# Altium schematic sheet Function.SchDoc(Function)
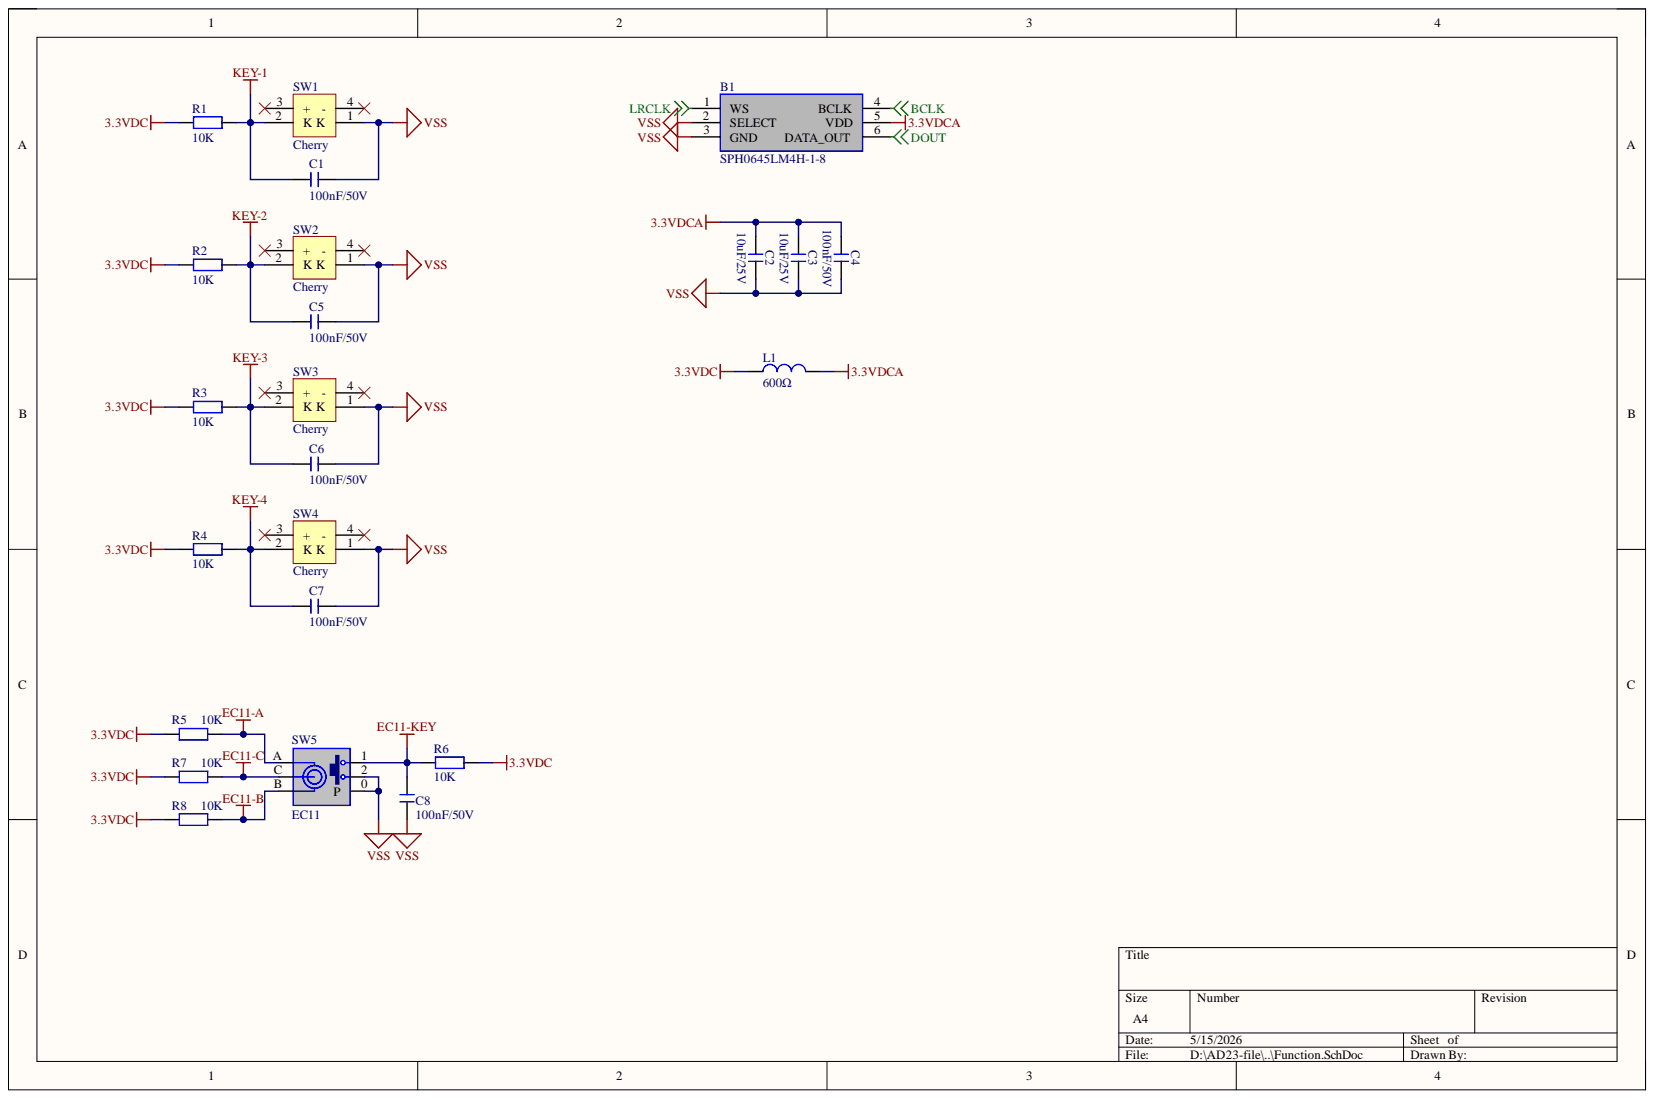
[diagram p0: Function.SchDoc]

## Parts
B1  SPH0645LM4H-1-8  [SPH0645LM4H18]
C1  100nF/50V  [C 0603_L]
C2  10uF/25V  [C 0603_L]
C3  10uF/25V  [C 0603_L]
C4  100nF/50V  [C 0603_L]
C5  100nF/50V  [C 0603_L]
C6  100nF/50V  [C 0603_L]
C7  100nF/50V  [C 0603_L]
C8  100nF/50V  [C 0603_L]
L1  600Ω  [L 0603]
R1  10K  [R 0603_L]
R2  10K  [R 0603_L]
R3  10K  [R 0603_L]
R4  10K  [R 0603_L]
R5  10K  [R 0603_L]
R6  10K  [R 0603_L]
R7  10K  [R 0603_L]
R8  10K  [R 0603_L]
SW1  Cherry  [LSW DIP-CHERRY]
SW2  Cherry  [LSW DIP-CHERRY]
SW3  Cherry  [LSW DIP-CHERRY]
SW4  Cherry  [LSW DIP-CHERRY]
SW5  EC11  [EC11-B]

## Nets
3.3VDC: L1.1 R1.1 R2.1 R3.1 R4.1 R5.1 R6.2 R7.1 R8.1
3.3VDCA: B1.5(VDD) C2.2 C3.2 C4.2 L1.2
BCLK: B1.4(BCLK)
DOUT: B1.6(DATA_OUT)
EC11-A: R5.2 SW5.A
EC11-B: R8.2 SW5.B
EC11-C: R7.2 SW5.C
EC11-KEY: C8.2 R6.1 SW5.1
KEY-1: C1.1 R1.2 SW1.2(K)
KEY-2: C5.1 R2.2 SW2.2(K)
KEY-3: C6.1 R3.2 SW3.2(K)
KEY-4: C7.1 R4.2 SW4.2(K)
LRCLK: B1.1(WS)
VSS: B1.2(SELECT) B1.3(GND) C1.2 C2.1 C3.1 C4.1 C5.2 C6.2 C7.2 C8.1 SW1.1(K) SW2.1(K) SW3.1(K) SW4.1(K) SW5.0(P) SW5.2

Not connected: SW1 3(+) 4(-); SW2 3(+) 4(-); SW3 3(+) 4(-); SW4 3(+) 4(-)

## Library data of the parts
B1 = SPH0645LM4H-1-8: MEMS Microphones SILICON MICROPHONE; Manufacturer_Name: Knowles; Geometry.Height: 1.08mm; Component Kind: Standard; Datasheet Link: https://www.knowles.com/docs/default-source/default-document-library/sph0645lm4h-1-datasheet.pdf?Status=Master&sfvrsn=751076b1_2; Library Name: 1.1-IC芯片.SchLib; Manufacturer_Part_Number: SPH0645LM4H-1-8; Mouser Part Number: 721-SPH0645LM4H-1-8; Mouser Price/Stock: https://www.mouser.co.uk/ProductDetail/Knowles/SPH0645LM4H-1-8?qs=TuK3vfAjtkXP9L009Fh60A%3D%3D
C1-C8 = C: 无极性贴片电容; 版权归属: 源创客; BOM名称: 无极性贴片电容; Component Kind: Standard; Library Name: 3.2-电容.SchLib; 学习资源: www.ooocke.com
L1 = L: 小功率贴片磁珠; BOM名称: 小功率贴片电感; 版权归属: 源创客; Component Kind: Standard; Library Name: 3.3-电感.SchLib; 学习资源: www.ooocke.com
R1-R8 = R: 贴片电阻; 版权归属: 源创客; BOM名称: 贴片电阻; Component Kind: Standard; Library Name: 3.1-电阻.SchLib; 学习资源: www.ooocke.com
SW1-SW4 = LSW: 带灯机械按键开关; 版权归属: 源创客; BOM名称: 带灯机械按键开关; Component Kind: Standard; Library Name: 6.1-按键.SchLib; 学习资源: www.ooocke.com
SW5 = EC11: 数字开关编码器; BOM名称: 数字编码开关; 版权归属: 源创客; Component Kind: Standard; Library Name: 6.2-开关.SchLib; 学习资源: www.ooocke.com
